# Altium schematic sheet Node.SchDoc(Node)
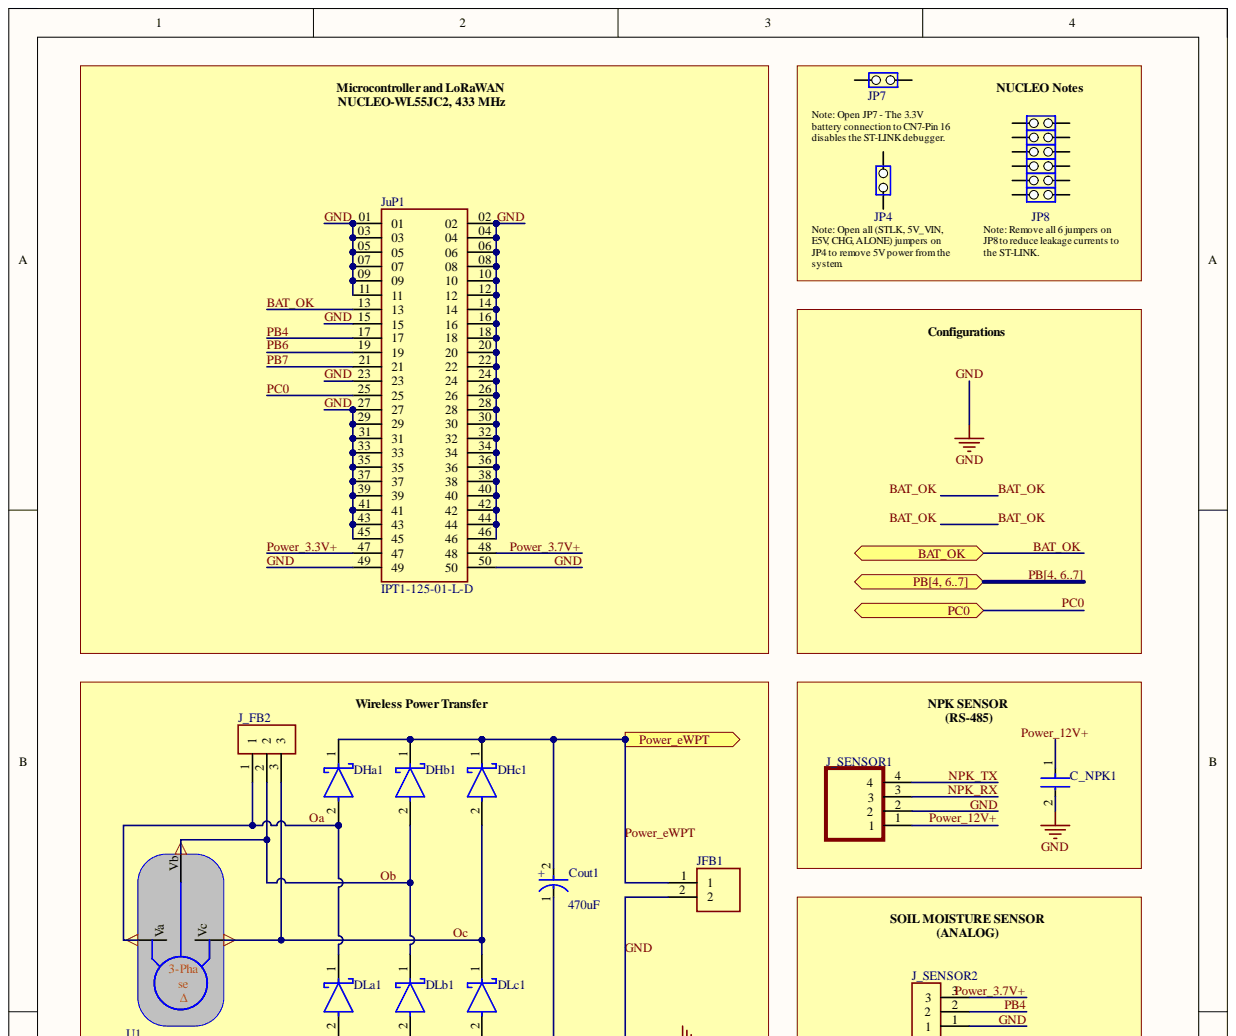
[diagram p0: Node.SchDoc, top]
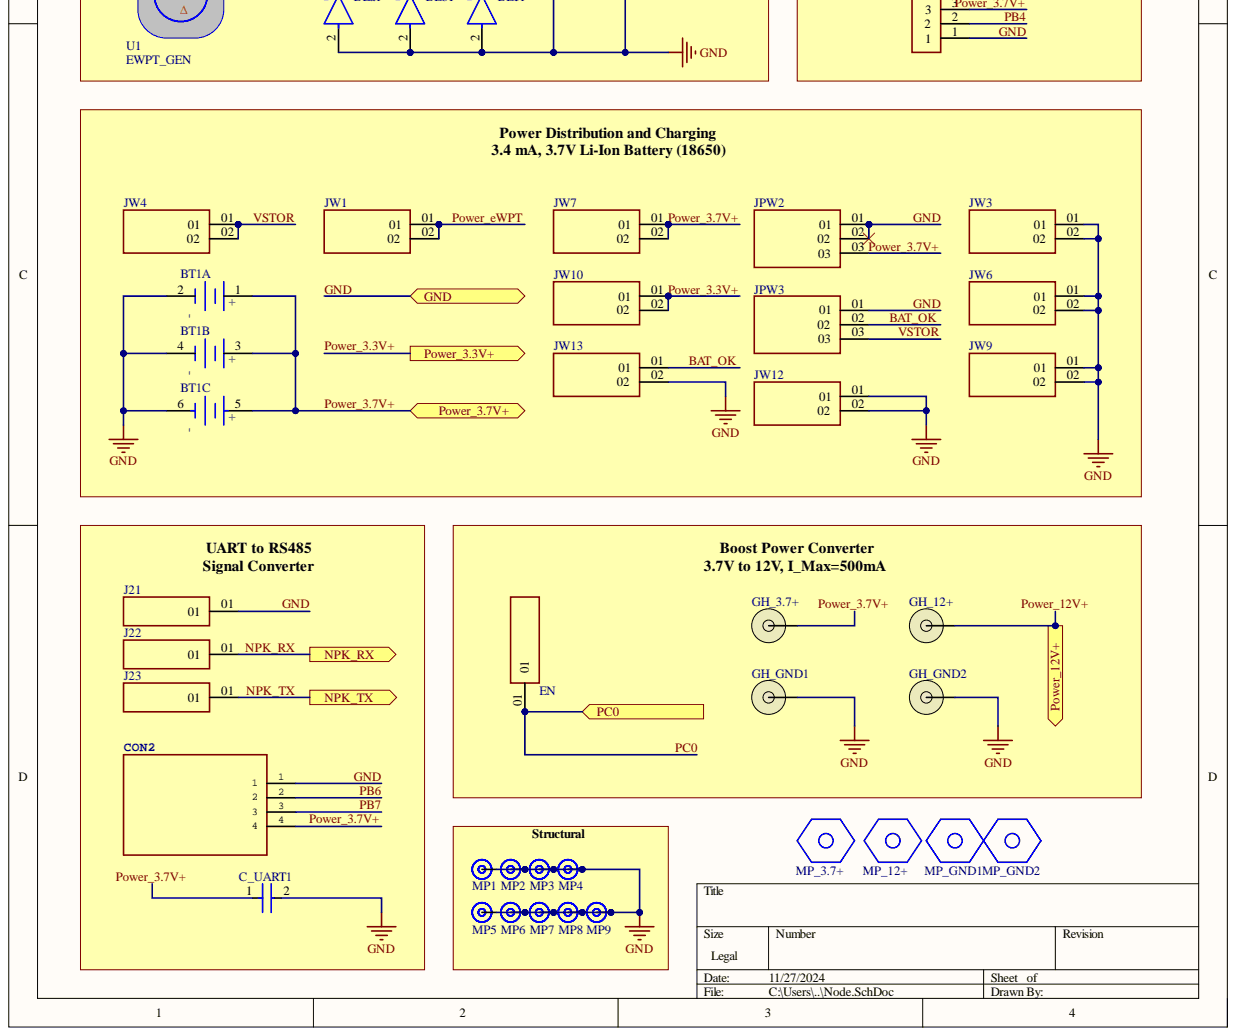
[diagram p1: Node.SchDoc, bottom]

## Parts
BT1  BK-18650-PC6  [FP-BK-18650-PC6-MFG]  (value not drawn)  (parts A,B,C)
C_NPK1  GRM188R60J106ME47D  [FP-GRM188-0_1-e0_2_0_55-IPC_A]  (value not drawn)
C_UART1  GRM188R60J106ME47D  [FP-GRM188-0_1-e0_2_0_55-IPC_A]  (value not drawn)
CON2  SAMTEC-BCS-104-X-S-TEJ  [SAMTEC-BCS-104-X-S-TE]  (value not drawn)
Cout1  Capacitance: 470uF  [FP-FTF-MFG]  (hidden comment: EEEFT1E471AP)
DHa1  BAT20JFILM  [FP-BAT20JFILM-MFG]  (value not drawn)
DHb1  BAT20JFILM  [FP-BAT20JFILM-MFG]  (value not drawn)
DHc1  BAT20JFILM  [FP-BAT20JFILM-MFG]  (value not drawn)
DLa1  BAT20JFILM  [FP-BAT20JFILM-MFG]  (value not drawn)
DLb1  BAT20JFILM  [FP-BAT20JFILM-MFG]  (value not drawn)
DLc1  BAT20JFILM  [FP-BAT20JFILM-MFG]  (value not drawn)
EN  Comment  [SAMTEC_BCS-101-L-S-TE]  (value not drawn)
GH_3.7+  Grounded Hole  [Hole, 3mm]  (value not drawn)  (no BOM)
GH_12+  Grounded Hole  [Hole, 3mm]  (value not drawn)  (no BOM)
GH_GND1  Grounded Hole  [Hole, 3mm]  (value not drawn)  (no BOM)
GH_GND2  Grounded Hole  [Hole, 3mm]  (value not drawn)  (no BOM)
J21  Comment  [SAMTEC_BCS-101-L-S-TE]  (value not drawn)
J22  bcs101lste  [SAMTEC_BCS-101-L-S-TE]  (value not drawn)
J23  Comment  [SAMTEC_BCS-101-L-S-TE]  (value not drawn)
J_FB2  282837-3  [TE_282837-3]  (value not drawn)
J_SENSOR1  282837-4  [TE_282837-4]  (value not drawn)
J_SENSOR2  282837-3  [TE_282837-3]  (value not drawn)
JFB1  282836-2  [TE_282836-2]  (value not drawn)
JPW2  SSQ-103-01-L-S  [SAMTEC_SSQ-103-01-L-S]  (value not drawn)
JPW3  SSQ-103-01-L-S  [SAMTEC_SSQ-103-01-L-S]  (value not drawn)
JuP1  IPT1-125-01-L-D  [SAMTEC_IPT1-125-01-L-D]
JW1  SSQ-102-01-L-S  [SAMTEC_SSQ-102-01-L-S]  (value not drawn)
JW3  SSQ-102-01-L-S  [SAMTEC_SSQ-102-01-L-S]  (value not drawn)
JW4  SSQ-102-01-L-S  [SAMTEC_SSQ-102-01-L-S]  (value not drawn)
JW6  SSQ-102-01-L-S  [SAMTEC_SSQ-102-01-L-S]  (value not drawn)
JW7  SSQ-102-01-L-S  [SAMTEC_SSQ-102-01-L-S]  (value not drawn)
JW9  SSQ-102-01-L-S  [SAMTEC_SSQ-102-01-L-S]  (value not drawn)
JW10  SSQ-102-01-L-S  [SAMTEC_SSQ-102-01-L-S]  (value not drawn)
JW12  SSQ-102-01-L-S  [SAMTEC_SSQ-102-01-L-S]  (value not drawn)
JW13  SSQ-102-01-L-S  [SAMTEC_SSQ-102-01-L-S]  (value not drawn)
MP1  Screw Mount, #4-40  [Screw Mount, #4-40]  (value not drawn)  (no BOM)
MP2  Screw Mount, #4-40  [Screw Mount, #4-40]  (value not drawn)  (no BOM)
MP3  Screw Mount, #4-40  [Screw Mount, #4-40]  (value not drawn)  (no BOM)
MP4  Screw Mount, #4-40  [Screw Mount, #4-40]  (value not drawn)  (no BOM)
MP5  Screw Mount, #4-40  [Screw Mount, #4-40]  (value not drawn)  (no BOM)
MP6  Screw Mount, #4-40  [Screw Mount, #4-40]  (value not drawn)  (no BOM)
MP7  Screw Mount, #4-40  [Screw Mount, #4-40]  (value not drawn)  (no BOM)
MP8  Screw Mount, #4-40  [Screw Mount, #4-40]  (value not drawn)  (no BOM)
MP9  Screw Mount, #4-40  [Screw Mount, #4-40]  (value not drawn)  (no BOM)
MP_3.7+  SO-1015-03-01-01  [FP-SO-1015-03-01-01-MFG]  (value not drawn)
MP_12+  SO-1015-03-01-01  [FP-SO-1015-03-01-01-MFG]  (value not drawn)
MP_GND1  SO-1015-03-01-01  [FP-SO-1015-03-01-01-MFG]  (value not drawn)
MP_GND2  SO-1015-03-01-01  [FP-SO-1015-03-01-01-MFG]  (value not drawn)

## Ports
GND, PA10, PB[4, 6..7], PC0, Power_3.3V+, Power_3.7V+, Power_12V+, Power_12V+_EN, Power_eWPT, RS485_A+, RS485_B-

## Nets
BAT_OK: JPW3.02 JuP1.13 JW13.01 ; port PA10
GND: BT1.2 BT1.4 BT1.6 C_NPK1.2 C_UART1.2 CON2.1 Cout1.1 DLa1.2 DLb1.2 DLc1.2 GH_GND1.1 GH_GND2.1 J21.01 J_SENSOR1.2 J_SENSOR2.1 JFB1.2 JPW2.01 JPW2.02 JPW3.01 JuP1.01 JuP1.02 JuP1.03 JuP1.04 JuP1.05 JuP1.06 JuP1.07 JuP1.08 JuP1.09 JuP1.10 JuP1.11 JuP1.12 JuP1.14 JuP1.15 JuP1.16 JuP1.18 JuP1.20 JuP1.22 JuP1.23 JuP1.24 JuP1.26 JuP1.27 JuP1.28 JuP1.29 JuP1.30 JuP1.31 JuP1.32 JuP1.33 JuP1.34 JuP1.35 JuP1.36 JuP1.37 JuP1.38 JuP1.39 JuP1.40 JuP1.41 JuP1.42 JuP1.43 JuP1.44 JuP1.45 JuP1.46 JuP1.49 JuP1.50 JW3.01 JW3.02 JW6.01 JW6.02 JW9.01 JW9.02 JW12.01 JW12.02 JW13.02 MP1.1 MP2.1 MP3.1 MP4.1 MP5.1 MP6.1 MP7.1 MP8.1 MP9.1 ; port GND
NPK_RX: J22.01 J_SENSOR1.3 ; port RS485_B-
NPK_TX: J23.01 J_SENSOR1.4 ; port RS485_A+
Oa: DHa1.2 DLa1.1 J_FB2.1
Ob: DHb1.2 DLb1.1 J_FB2.2
Oc: DHc1.2 DLc1.1 J_FB2.3
PB4: J_SENSOR2.2 JuP1.17
PB6: CON2.2 JuP1.19
PB7: CON2.3 JuP1.21
PC0: EN.01 JuP1.25 ; port PC0, port Power_12V+_EN
Power_3.3V+: JuP1.47 JW10.01 JW10.02 ; port Power_3.3V+
Power_3.7V+: BT1.1 BT1.3 BT1.5 C_UART1.1 CON2.4 GH_3.7+.1 J_SENSOR2.3 JPW2.03 JuP1.48 JW7.01 JW7.02 ; port Power_3.7V+
Power_12V+: C_NPK1.1 GH_12+.1 J_SENSOR1.1 ; port Power_12V+
Power_eWPT: Cout1.2 DHa1.1 DHb1.1 DHc1.1 JFB1.1 JW1.01 JW1.02 ; port Power_eWPT
VSTOR: JPW3.03 JW4.01 JW4.02
PB[4, 6..7]: ; port PB[4, 6..7]

## Library data of the parts
BT1 = CMP-136234-000001-1: BATT HOLDER 18650 3 CELL PC PIN; Manufacturer: MPD (Memory Protection Devices); Conn Gender: Female; Conn Orientation: Top View; Number Of Contacts: 3; Pitch: 72.9mm; Automotive: No; Category: Batt; Component Kind: Standard; ComponentLink2Description: Datasheet; ComponentLink2URL: http://www.memoryprotectiondevices.com/datasheets/BK-18650-PC6-datasheet.pdf; Contact Material: Nickel; Device Class L1: Connectors; Device Class L2: Power Connectors; Device Class L3: unset; Digikey Description: BATT HOLDER 18650 3 CELL PC PIN; Height: 22.04mm; Lead Free: Yes; Library Name: Altium Content Vault; Manufacturer Part Number: BK-18650-PC6; Octopart: https://octopart.com/bk-18650-pc6-memory+protection+devices-19049091; Package: 0; RoHS: TRUE; Temperature: -40°C to +180°C; Temperature Range High: +180°C; Temperature Range Low: -40°C
C_NPK1 C_UART1 = CMP-2006-02792-2: Chip Multilayer Ceramic Capacitors for General Purpose, 0603, 10uF, X5R, 15%, 20%, 6.3V; Capacitance: 10uF; Case Code (Imperial): 0603; Case Code (Metric): 1608; Case/Package: 0603; Component Kind: Standard; Depth: 0.8mm; Dielectric: X5R; Height: 0.8mm; Lead Free: Lead Free; Length: 1.6mm; Library Name: Altium Content Vault; Material: Ceramic; Max Operating Temperature: 85°C; Min Operating Temperature: -55°C; Mount: Surface Mount; Package Quantity: 4000; Packaging: Tape and Reel; Radiation Hardening: No; REACH SVHC: No SVHC; Termination: SMD/SMT; Thickness: 0.035inch; Tolerance: 20%; Voltage: 6.3V; Voltage Rating: 6.3V; Voltage Rating (DC): 6.3V; Width: 0.031inch
Cout1 = CMP-05427-000040-1: CAP ALUM 470UF 20% 25V SMD; Dielectric Material: Aluminium; ESR (Equivalent Series Resistance): 80mR; Lead Diameter: 0.9mm; Leakage Current: 117.5uA; Life (Hours): 2000Hour; Ripple Current: 850mA; Case/Package: Radial; Component Kind: Standard; Construction: Cylindrical; Contact Plating: Tin; Diameter: 8mm; Features: General Purpose; Height: 10.2mm; Height - Seated (Max): 0.413inch; Lead Free: Lead Free; Lead Pitch: 3.1mm; Length: 10.2mm; Library Name: Altium Content Vault; Max Operating Temperature: 105°C; Min Operating Temperature: -55°C; Mount: Surface Mount; Number of Pins: 2; Package Quantity: 500; Packaging: Tape and Reel; Radiation Hardening: No; REACH SVHC: No SVHC; Ripple Current (AC): 850mA; RoHS Compliant: Yes; Series: FT; Termination: SMD/SMT; Tolerance: 20%; Voltage: 25V; Voltage Rating: 25V; Voltage Rating (DC): 25V
DHa1 DHb1 DHc1 DLa1 DLb1 DLc1 = CMP-12187-000030-1: DIODE SCHOTTKY 23V 1A SOD323; Capacitance: 30pF; Element Configuration: Single; Forward Voltage: 620mV; Max Forward Surge Current (Ifsm): 5A; Max Reverse Leakage Current: 12uA; Polarity: Standard; Average Rectified Current: 1A; Component Kind: Standard; Current Rating: 1A; Forward Current: 1A; Height: 1.03mm; Lead Free: Lead Free; Length: 1.8mm; Library Name: Altium Content Vault; Max Operating Temperature: 150°C; Max Repetitive Reverse Voltage (Vrrm): 23V; Max Reverse Voltage (DC): 23V; Max Surge Current: 5A; Min Operating Temperature: -65°C; Mount: Surface Mount; Number of Pins: 2; Output Current: 1A; Package Quantity: 1; Packaging: Tape and Reel; Peak Non-Repetitive Surge Current: 5A; Peak Reverse Current: 12uA; Radiation Hardening: No; REACH SVHC: No SVHC; Voltage Rating (DC): 23V; Weight: 0.01lb; Width: 1.35mm
EN J21-J23 = BCS-101-L-S-TE: MF: {'manufacturer': u'Samtec Inc.'}; Availability: Unavailable; Component Kind: Standard; Library Name: BCS-101-L-S-TE.IntLib; MP: BCS-101-L-S-TE; Package: None; Price: None
GH_3.7+ GH_12+ GH_GND1 GH_GND2 = Hole, 3mm: Library Name: DansComponents.SchLib
J_FB2 J_SENSOR2 = 282837-3: TERMI-BLOK PCB MOUNT 3P; Availability: Unavailable; Component Kind: Standard; Library Name: 282837-3.IntLib; MP: 282837-3; Package: None; Price: None
J_SENSOR1 = 282837-4: Modular Terminal Block, 13.5A, 1.5mm2, 1 Row(s), 1 Deck(s); Manufacturer: TE Connectivity; Fastening Method: SCREW; Number of Decks: 1; Number of Ways: 4; Safety Approval: UL; Voltage Rating: 300V; Wire/Cable Gauge: 15AWG; Availability: In Stock; Category: Terminal Blocks; Check_prices: https://www.snapeda.com/parts/282837-4/TE+Connectivity+AMP+Connectors/view-part/?ref=eda; Component Kind: Standard; ComponentLink1Description: https://datasheet.ciiva.com:443/pdfs/VipMasterIC/IC/TYEL/TYEL-S-A0008869674/TYEL-S-A0008857185-1.pdf?src-supplier=IHS+Markit; ComponentLink1URL: https://datasheet.ciiva.com:443/pdfs/VipMasterIC/IC/TYEL/TYEL-S-A0008869674/TYEL-S-A0008857185-1.pdf?src-supplier=IHS+Markit; ComponentLink2Description: https://datasheet.ciiva.com/16305/282837-4-16305251.pdf?src-supplier=Future+Electronics; ComponentLink2URL: https://datasheet.ciiva.com/16305/282837-4-16305251.pdf?src-supplier=Future+Electronics; ComponentLink3Description: https://datasheet.ciiva.com/11757/0900766b80e7dc97-11757520.pdf?src-supplier=Rs; ComponentLink3URL: https://datasheet.ciiva.com/11757/0900766b80e7dc97-11757520.pdf?src-supplier=Rs; ComponentLink4Description: https://datasheet.ciiva.com/3141/eng-cs-1308389-eurostyle-terminal-blocks-0607-3141581.pdf?src-supplier=Avnet; ComponentLink4URL: https://datasheet.ciiva.com/3141/eng-cs-1308389-eurostyle-terminal-blocks-0607-3141581.pdf?src-supplier=Avnet; ComponentLink5Description: https://datasheet.ciiva.com/823/eng-cd-282837-g3-823861.pdf?src-supplier=Digikey; ComponentLink5URL: https://datasheet.ciiva.com/823/eng-cd-282837-g3-823861.pdf?src-supplier=Digikey; ComponentLink6Description: https://datasheet.ciiva.com/30086/eng-cs-1308389-eurostyle-terminal-blocks-0607-30086603.pdf?src-supplier=Onlinecomponents.com; ComponentLink6URL: https://datasheet.ciiva.com/30086/eng-cs-1308389-eurostyle-terminal-blocks-0607-30086603.pdf?src-supplier=Onlinecomponents.com; ComponentLink7Description: https://datasheet.ciiva.com/15169/getdatasheetpartid-227114-15169974.pdf?src-supplier=Verical; ComponentLink7URL: https://datasheet.ciiva.com/15169/getdatasheetpartid-227114-15169974.pdf?src-supplier=Verical; ComponentLink8Description: https://datasheet.ciiva.com/7978/1650926-7978571.pdf?src-supplier=Element14; ComponentLink8URL: https://datasheet.ciiva.com/7978/1650926-7978571.pdf?src-supplier=Element14; Current Rating: 13.5A; EU_RoHS_Compliance: Compliant with Exemptions; Library Name: 282837-4.IntLib; Manufacturer Part Number: 282837-4; MF: TE Connectivity; Mounting Technology: Board; MP: 282837-4; Number of Rows: 1; Package: None; Price: None; Pricing: 1=1.08, 10=1.04, 25=0.993, 50=0.917, 100=0.831 (USD); Purchase-URL: https://pricing.snapeda.com/search/part/282837-4/?ref=eda; Reach SVHC Compliant: No; RoHS: Yes; RoHS Compliant: Yes; SnapEDA_Link: https://www.snapeda.com/parts/282837-4/TE+Connectivity+AMP+Connectors/view-part/?ref=snap; Stock: 609; Supplier: Avnet; Supplier 1: Digikey; Supplier 2: Mouser; Supplier Part Number: 90C1040; Supplier Part Number 1: A124423-ND; Supplier Part Number 2: 571-2828374; Terminal and Terminal Block Type: MODULAR TERMINAL BLOCK; Wire Cross Section: 1.5mm2
JFB1 = 282836-2: Connector, Terminal Block; Wire Receptacle; 2 Pos.; 16-30 AWG; 0.197 in. CL; Sid; MF: TE Connectivity; Availability: In Stock; Check_prices: https://www.snapeda.com/parts/282836-2/TE+Connectivity+AMP+Connectors/view-part/?ref=eda; Component Kind: Standard; Library Name: 282836-2.IntLib; MP: 282836-2; Package: None; Price: None; Purchase-URL: https://pricing.snapeda.com/search/part/282836-2/?ref=eda; SnapEDA_Link: https://www.snapeda.com/parts/282836-2/TE+Connectivity+AMP+Connectors/view-part/?ref=snap
JPW2 JPW3 = SSQ-103-01-L-S: MF: Samtec; Availability: Unavailable; Component Kind: Standard; Library Name: SSQ-103-01-L-S.IntLib; MP: SSQ-103-01-L-S; Package: None; Price: None
JW1 JW3 JW4 JW6 JW7 JW9 JW10 JW12 JW13 = SSQ-102-01-L-S: MF: Samtec; Availability: Unavailable; Component Kind: Standard; Library Name: SSQ-102-01-L-S.IntLib; MP: SSQ-102-01-L-S; Package: None; Price: None
MP_3.7+ MP_12+ MP_GND1 MP_GND2 = CMP-02780-000001-1: HEX STANDOFF 10MM; Gender: Male, Female; Thread Size: #4-40; Threaded/Unthreaded: Threaded; Component Kind: Standard; Height: 10mm; Library Name: Altium Content Vault; Material: Aluminium; Series: 4-20HR-MaxSonar-WR
CON2 = SAMTEC-BCS-104-X-S-TEJ: Component Kind: Standard; Library Name: SAMTEC-BCS-104-X-S-TE.IntLib
JuP1 = IPT1-125-01-L-D: Availability: Unavailable; Component Kind: Standard; Library Name: IPT1-125-01-L-D.IntLib; MP: IPT1-125-01-L-D; Package: None; Price: None
MP1-MP9 = Screw Mount, #4-40: Library Name: DansComponents.SchLib
